# Altium schematic sheet RTC.SchDoc
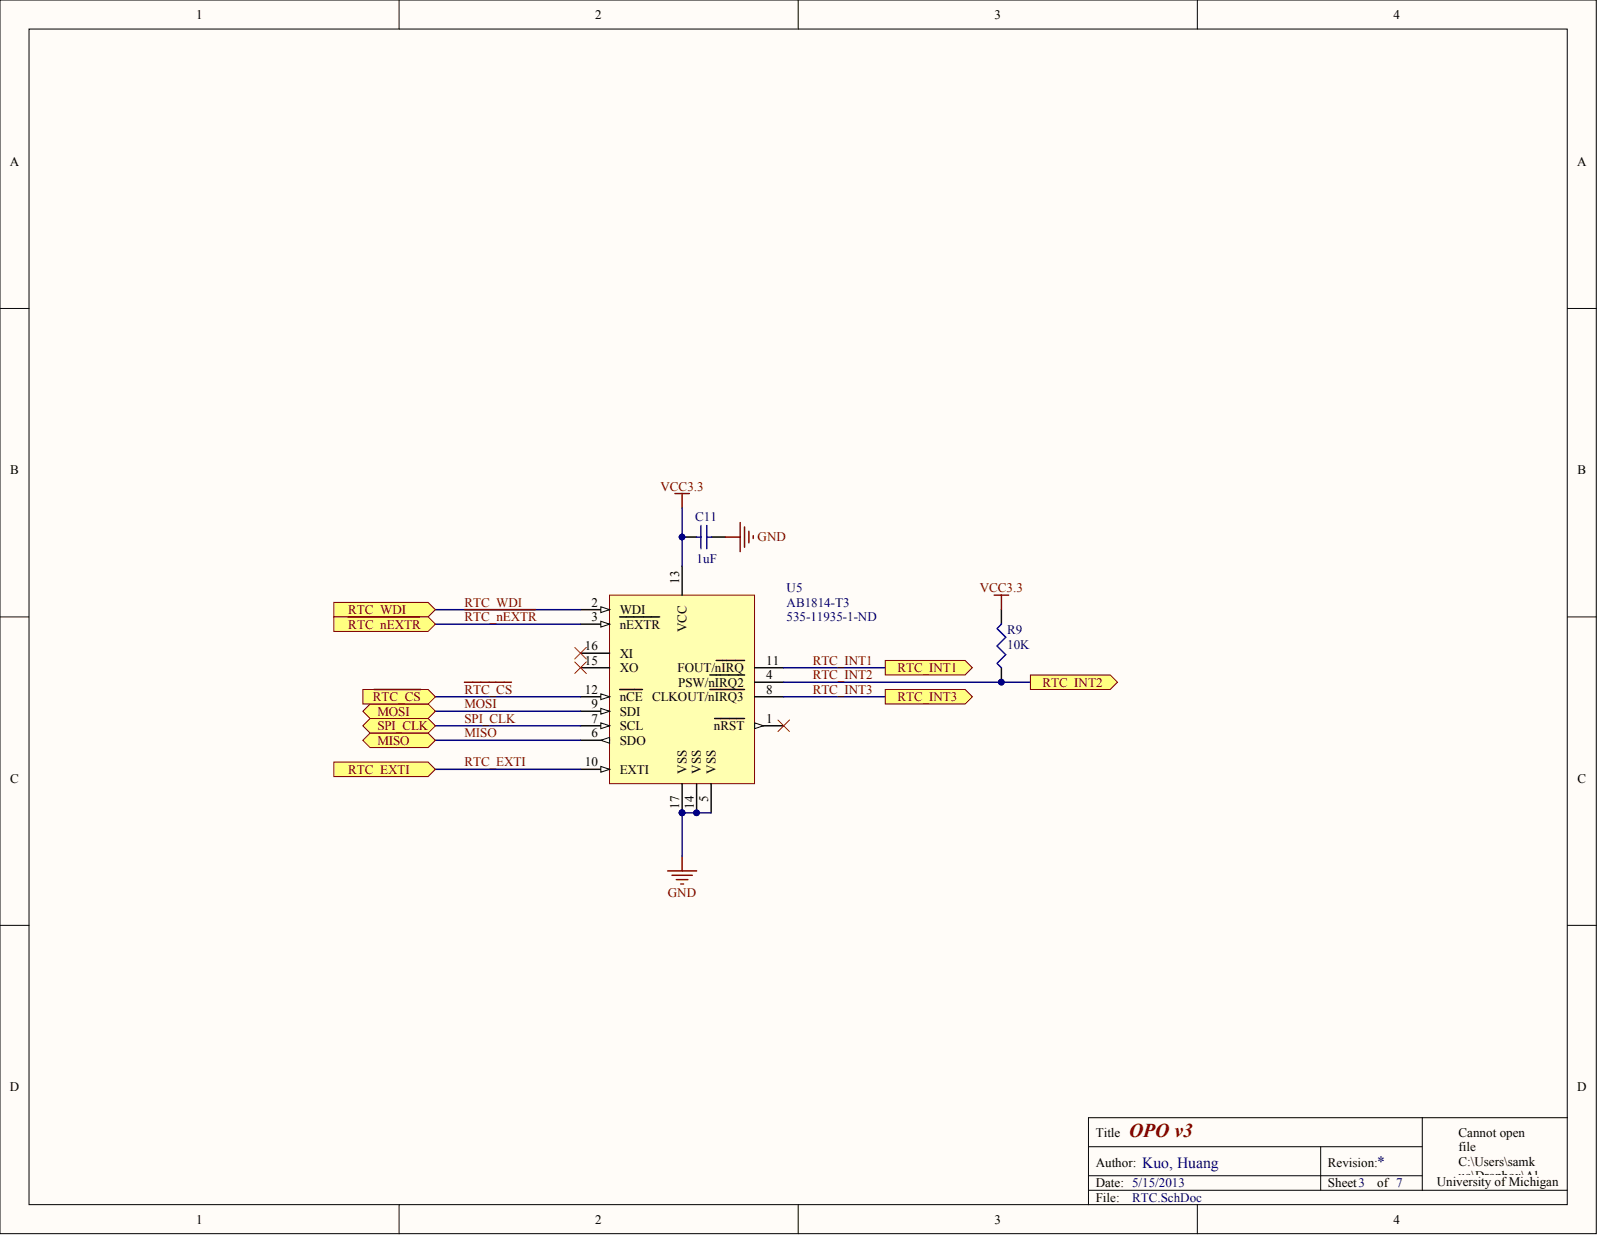
[diagram p0: RTC.SchDoc]

## Parts
C11  value 1uF  (hidden comment: Cap Semi)
R9  value 10K  (hidden comment: Res3)
U5  AB1814-T3  Supplier Part Number 1: 535-11935-1-ND

## Ports
MISO, MOSI, RTC_EXTI, RTC_INT1, RTC_INT2, RTC_INT3, RTC_WDI, SPI_CLK, ~{RTC_CS}, ~{RTC_NEXTR}

## Nets
GND: C11.2 U5.5(VSS) U5.14(VSS) U5.17(VSS)
VCC3.3: C11.1 R9.2 U5.13(VCC)
MISO: U5.6(SDO) ; port MISO
MOSI: U5.9(SDI) ; port MOSI
~{RTC_CS}: U5.12(nCE) ; port ~{RTC_CS}
~{RTC_NEXTR}: U5.3(nEXTR) ; port ~{RTC_NEXTR}
RTC_EXTI: U5.10(EXTI) ; port RTC_EXTI
RTC_INT1: U5.11(FOUT/nIRQ) ; port RTC_INT1
RTC_INT2: R9.1 U5.4(PSW/nIRQ2) ; port RTC_INT2
RTC_INT3: U5.8(CLKOUT/nIRQ3) ; port RTC_INT3
RTC_WDI: U5.2(WDI) ; port RTC_WDI
SPI_CLK: U5.7(SCL) ; port SPI_CLK

Not connected: U5 1(nRST) 15(XO) 16(XI)

## Library data of the parts
C11 = (no symbol name): Value: 1uF; Published: 8-Jun-2000; LatestRevisionDate: 29-May-2009; LatestRevisionNote: IPC-7351 Footprint Added.; PackageReference: C1206; PackageDescription: Chip Capacitor; Publisher: Altium Limited
R9 U5 = (no symbol name)
  R9: Published: 8-Jun-2000; LatestRevisionDate: 29-May-2009; LatestRevisionNote: IPC-7351 Footprint Added.; PackageReference: J1-0603; PackageDescription: Chip Resistor; Publisher: Altium Limited
  U5: Supplier 1: Digi-Key
